# Altium schematic sheet Drivers.SchDoc(Drivers)
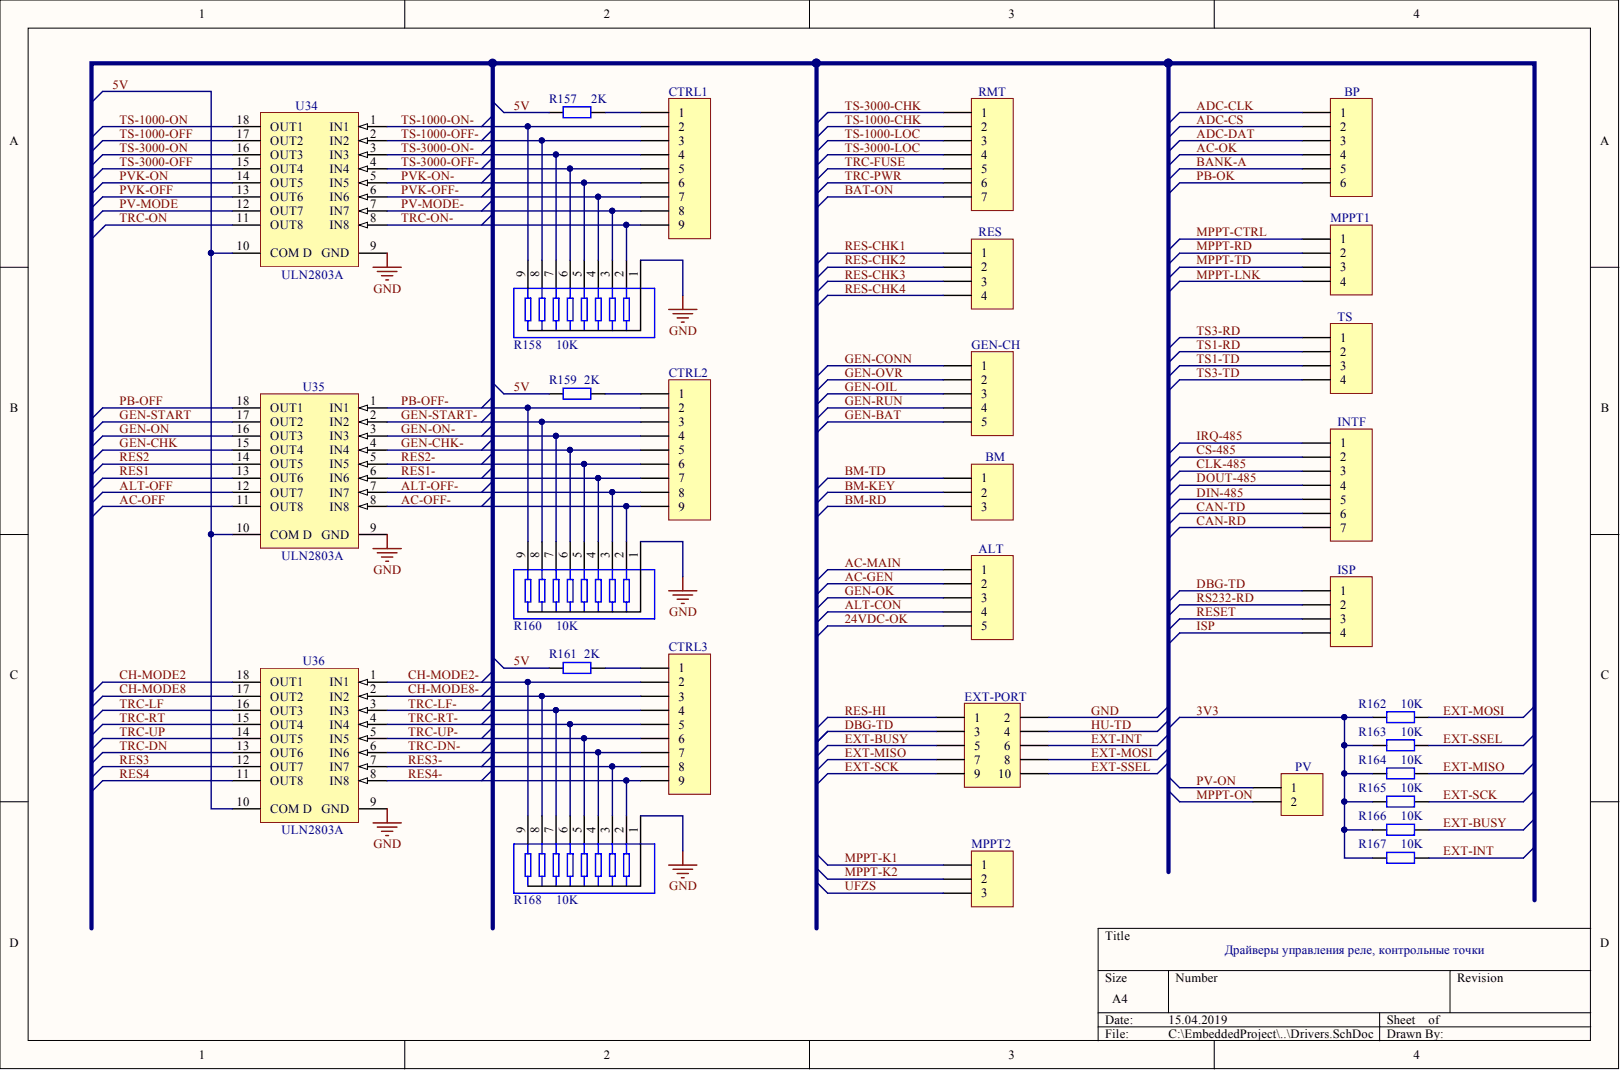
[diagram p0: Drivers.SchDoc]

## Parts
ALT  Header 5  [HDR1X5]  (value not drawn)
BM  Header 3  [HDR1X3]  (value not drawn)
BP  Header 6  [HDR1X6]  (value not drawn)
CTRL1  Header, 9-Pin  [HDR1X9]  (value not drawn)
CTRL2  Header, 9-Pin  [HDR1X9]  (value not drawn)
CTRL3  Header, 9-Pin  [HDR1X9]  (value not drawn)
EXT-PORT  Header, 10-Pin  [IDC10]  (value not drawn)
GEN-CH  Header 5  [HDR1X5]  (value not drawn)
INTF  Header 7  [HDR1X7]  (value not drawn)
ISP  Header 4  [HDR1X4]  (value not drawn)
MPPT1  Header 4  [HDR1X4]  (value not drawn)
MPPT2  Header 3  [HDR1X3]  (value not drawn)
PV  Header 2  [HDR1X2]  (value not drawn)
R157  value 2K  [1206]  (hidden comment: Res2)
R158  value 10K  [RM9]  (hidden comment: RES PACK8)
R159  value 2K  [1206]  (hidden comment: Res2)
R160  value 10K  [RM9]  (hidden comment: RES PACK8)
R161  value 2K  [1206]  (hidden comment: Res2)
R162  value 10K  [1206]  (hidden comment: Res2)
R163  value 10K  [1206]  (hidden comment: Res2)
R164  value 10K  [1206]  (hidden comment: Res2)
R165  value 10K  [1206]  (hidden comment: Res2)
R166  value 10K  [1206]  (hidden comment: Res2)
R167  value 10K  [1206]  (hidden comment: Res2)
R168  value 10K  [RM9]  (hidden comment: RES PACK8)
RES  Header 4  [HDR1X4]  (value not drawn)
RMT  Header 7  [HDR1X7]  (value not drawn)
TS  Header 4  [HDR1X4]  (value not drawn)
U34  ULN2803A  [DIP18]
U35  ULN2803A  [DIP18]
U36  ULN2803A  [DIP18]

## Nets
3V3: R162.2 R163.2 R164.2 R165.2 R166.2 R167.2
5V: R157.2 R159.2 R161.2 U34.10(COM_D) U35.10(COM_D) U36.10(COM_D)
24VDC-OK: ALT.5
AC-GEN: ALT.2
AC-MAIN: ALT.1
AC-OFF: U35.11(OUT8)
AC-OFF-: CTRL2.9 R160.2 U35.8(IN8)
AC-OK: BP.4
ADC-CLK: BP.1
ADC-CS: BP.2
ADC-DAT: BP.3
ALT-CON: ALT.4
ALT-OFF: U35.12(OUT7)
ALT-OFF-: CTRL2.8 R160.3 U35.7(IN7)
BANK-A: BP.5
BAT-ON: RMT.7
BM-KEY: BM.2
BM-RD: BM.3
BM-TD: BM.1
CAN-RD: INTF.7
CAN-TD: INTF.6
CH-MODE2: U36.18(OUT1)
CH-MODE2-: CTRL3.2 R168.9 U36.1(IN1)
CH-MODE8: U36.17(OUT2)
CH-MODE8-: CTRL3.3 R168.8 U36.2(IN2)
CLK-485: INTF.3
CS-485: INTF.2
DBG-TD: EXT-PORT.3 ISP.1
DIN-485: INTF.5
DOUT-485: INTF.4
EXT-BUSY: EXT-PORT.5 R166.1
EXT-INT: EXT-PORT.6 R167.1
EXT-MISO: EXT-PORT.7 R164.1
EXT-MOSI: EXT-PORT.8 R162.1
EXT-SCK: EXT-PORT.9 R165.1
EXT-SSEL: EXT-PORT.10 R163.1
GEN-BAT: GEN-CH.5
GEN-CHK: U35.15(OUT4)
GEN-CHK-: CTRL2.5 R160.6 U35.4(IN4)
GEN-CONN: GEN-CH.1
GEN-OIL: GEN-CH.3
GEN-OK: ALT.3
GEN-ON: U35.16(OUT3)
GEN-ON-: CTRL2.4 R160.7 U35.3(IN3)
GEN-OVR: GEN-CH.2
GEN-RUN: GEN-CH.4
GEN-START: U35.17(OUT2)
GEN-START-: CTRL2.3 R160.8 U35.2(IN2)
GND: EXT-PORT.2 R158.1 R160.1 R168.1 U34.9(GND) U35.9(GND) U36.9(GND)
HU-TD: EXT-PORT.4
IRQ-485: INTF.1
ISP: ISP.4
MPPT-CTRL: MPPT1.1
MPPT-K1: MPPT2.1
MPPT-K2: MPPT2.2
MPPT-LNK: MPPT1.4
MPPT-ON: PV.2
MPPT-RD: MPPT1.2
MPPT-TD: MPPT1.3
PB-OFF: U35.18(OUT1)
PB-OFF-: CTRL2.2 R160.9 U35.1(IN1)
PB-OK: BP.6
PV-MODE: U34.12(OUT7)
PV-MODE-: CTRL1.8 R158.3 U34.7(IN7)
PV-ON: PV.1
PVK-OFF: U34.13(OUT6)
PVK-OFF-: CTRL1.7 R158.4 U34.6(IN6)
PVK-ON: U34.14(OUT5)
PVK-ON-: CTRL1.6 R158.5 U34.5(IN5)
RES1: U35.13(OUT6)
RES1-: CTRL2.7 R160.4 U35.6(IN6)
RES2: U35.14(OUT5)
RES2-: CTRL2.6 R160.5 U35.5(IN5)
RES3: U36.12(OUT7)
RES3-: CTRL3.8 R168.3 U36.7(IN7)
RES4: U36.11(OUT8)
RES4-: CTRL3.9 R168.2 U36.8(IN8)
RES-CHK1: RES.1
RES-CHK2: RES.2
RES-CHK3: RES.3
RES-CHK4: RES.4
RES-HI: EXT-PORT.1
RESET: ISP.3
RS232-RD: ISP.2
TRC-DN: U36.13(OUT6)
TRC-DN-: CTRL3.7 R168.4 U36.6(IN6)
TRC-FUSE: RMT.5
TRC-LF: U36.16(OUT3)
TRC-LF-: CTRL3.4 R168.7 U36.3(IN3)
TRC-ON: U34.11(OUT8)
TRC-ON-: CTRL1.9 R158.2 U34.8(IN8)
TRC-PWR: RMT.6
TRC-RT: U36.15(OUT4)
TRC-RT-: CTRL3.5 R168.6 U36.4(IN4)
TRC-UP: U36.14(OUT5)
TRC-UP-: CTRL3.6 R168.5 U36.5(IN5)
TS1-RD: TS.2
TS1-TD: TS.3
TS3-RD: TS.1
TS3-TD: TS.4
TS-1000-CHK: RMT.2
TS-1000-LOC: RMT.3
TS-1000-OFF: U34.17(OUT2)
TS-1000-OFF-: CTRL1.3 R158.8 U34.2(IN2)
TS-1000-ON: U34.18(OUT1)
TS-1000-ON-: CTRL1.2 R158.9 U34.1(IN1)
TS-3000-CHK: RMT.1
TS-3000-LOC: RMT.4
TS-3000-OFF: U34.15(OUT4)
TS-3000-OFF-: CTRL1.5 R158.6 U34.4(IN4)
TS-3000-ON: U34.16(OUT3)
TS-3000-ON-: CTRL1.4 R158.7 U34.3(IN3)
UFZS: MPPT2.3
NetCTRL1_1: CTRL1.1 R157.1
NetCTRL2_1: CTRL2.1 R159.1
NetCTRL3_1: CTRL3.1 R161.1
N00247:

## Library data of the parts
ALT GEN-CH = Header 5: Header, 5-Pin; Component Kind: Standard; LatestRevisionDate: 17-Jul-2002; LatestRevisionNote: Re-released for DXP Platform.; Library Name: Miscellaneous Connectors.IntLib; Signal Integrity: Connector; Value: Header 5; Publisher: Altium Limited
BM MPPT2 = Header 3: Header, 3-Pin; Component Kind: Standard; LatestRevisionDate: 17-Jul-2002; LatestRevisionNote: Re-released for DXP Platform.; Library Name: Miscellaneous Connectors.IntLib; Signal Integrity: Connector; value: Header 3; Publisher: Altium Limited
BP = Header 6: Header, 6-Pin; Component Kind: Standard; LatestRevisionDate: 17-Jul-2002; LatestRevisionNote: Re-released for DXP Platform.; Library Name: Miscellaneous Connectors.IntLib; Signal Integrity: Connector; Value: Header 6; Publisher: Altium Limited
CTRL1-CTRL3 = Header 9: Component Kind: Standard; Description: Header, 9-Pin; LatestRevisionDate: 17-Jul-2002; LatestRevisionNote: Re-released for DXP Platform.; Library Name: Miscellaneous Connectors.IntLib; Signal Integrity: Connector; value: Header 9; Publisher: Altium Limited
EXT-PORT = Header 5X2: value: IDC-10M; Component Kind: Standard; Description: Header, 10-Pin; LatestRevisionDate: 17-Jul-2002; LatestRevisionNote: Re-released for DXP Platform.; Library Name: Miscellaneous Connectors.IntLib; Signal Integrity: Connector; Publisher: Altium Limited
INTF RMT = Header 7: Header, 7-Pin; Component Kind: Standard; LatestRevisionDate: 17-Jul-2002; LatestRevisionNote: Re-released for DXP Platform.; Library Name: Miscellaneous Connectors.IntLib; Signal Integrity: Connector; VALUE: Header 7; Publisher: Altium Limited
ISP MPPT1 RES TS = Header 4: Header, 4-Pin; Component Kind: Standard; LatestRevisionDate: 17-Jul-2002; LatestRevisionNote: Re-released for DXP Platform.; Library Name: Miscellaneous Connectors.IntLib; Signal Integrity: Connector; value: Header 4; Publisher: Altium Limited
PV = Header 2: Header, 2-Pin; Component Kind: Standard; LatestRevisionDate: 17-Jul-2002; LatestRevisionNote: Re-released for DXP Platform.; Library Name: Miscellaneous Connectors.IntLib; Signal Integrity: Connector; Value: Header, 2; Publisher: Altium Limited
R157 R159 R161-R167 = Res2: Resistor; Component Kind: Standard; LatestRevisionDate: 17-Jul-2002; LatestRevisionNote: Re-released for DXP Platform.; Library Name: Miscellaneous Devices.IntLib; PackageReference: AXIAL-0.4; Published: 8-Jun-2000; Signal Integrity: Res; Simulation: RESISTOR; Publisher: Altium Limited
R158 R160 R168 = RES PACK8: Isolated Resistor Network; Code_IPC: SOP65P780-16; Code_JEDEC: MO-150-AC; Component Kind: Standard; LatestRevisionDate: 29-May-2009; LatestRevisionNote: IPC-7351 Footprint Added.; Library Name: Schlib1.SchLib; PackageDescription: 16-Pin Plastic Small Outline Package 0.65 mm Pitch; PackageReference: SSOP16; PackageVersion: Oct-2003; Published: 24-Mar-1999; Simulation: respack_8; Publisher: Altium Limited
U34-U36 = ULN2803A: High-Voltage, High-Current Darlington Array; Component Kind: Standard; Library Name: Schlib1.SchLib; VALUE: ULN2803A
